# Altium schematic sheet Battery Management System.SchDoc
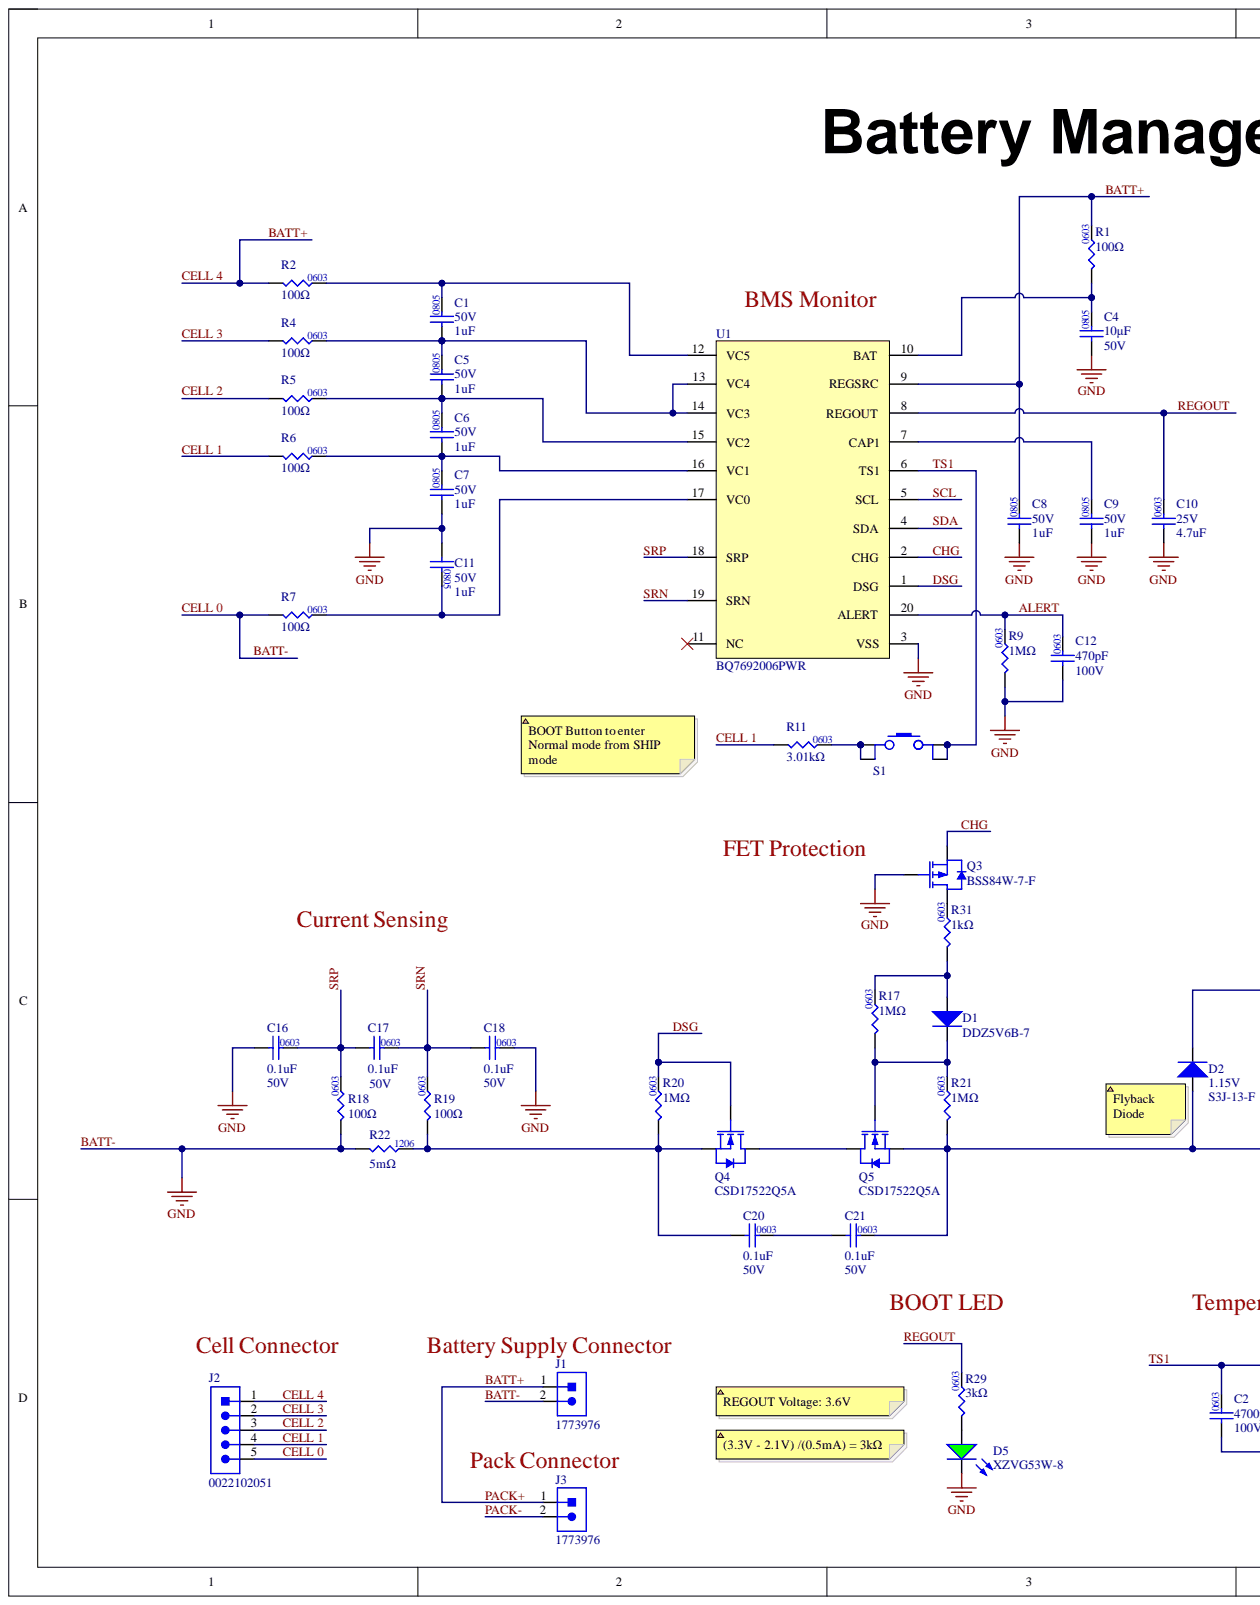
[diagram p0: Battery Management System.SchDoc, left]
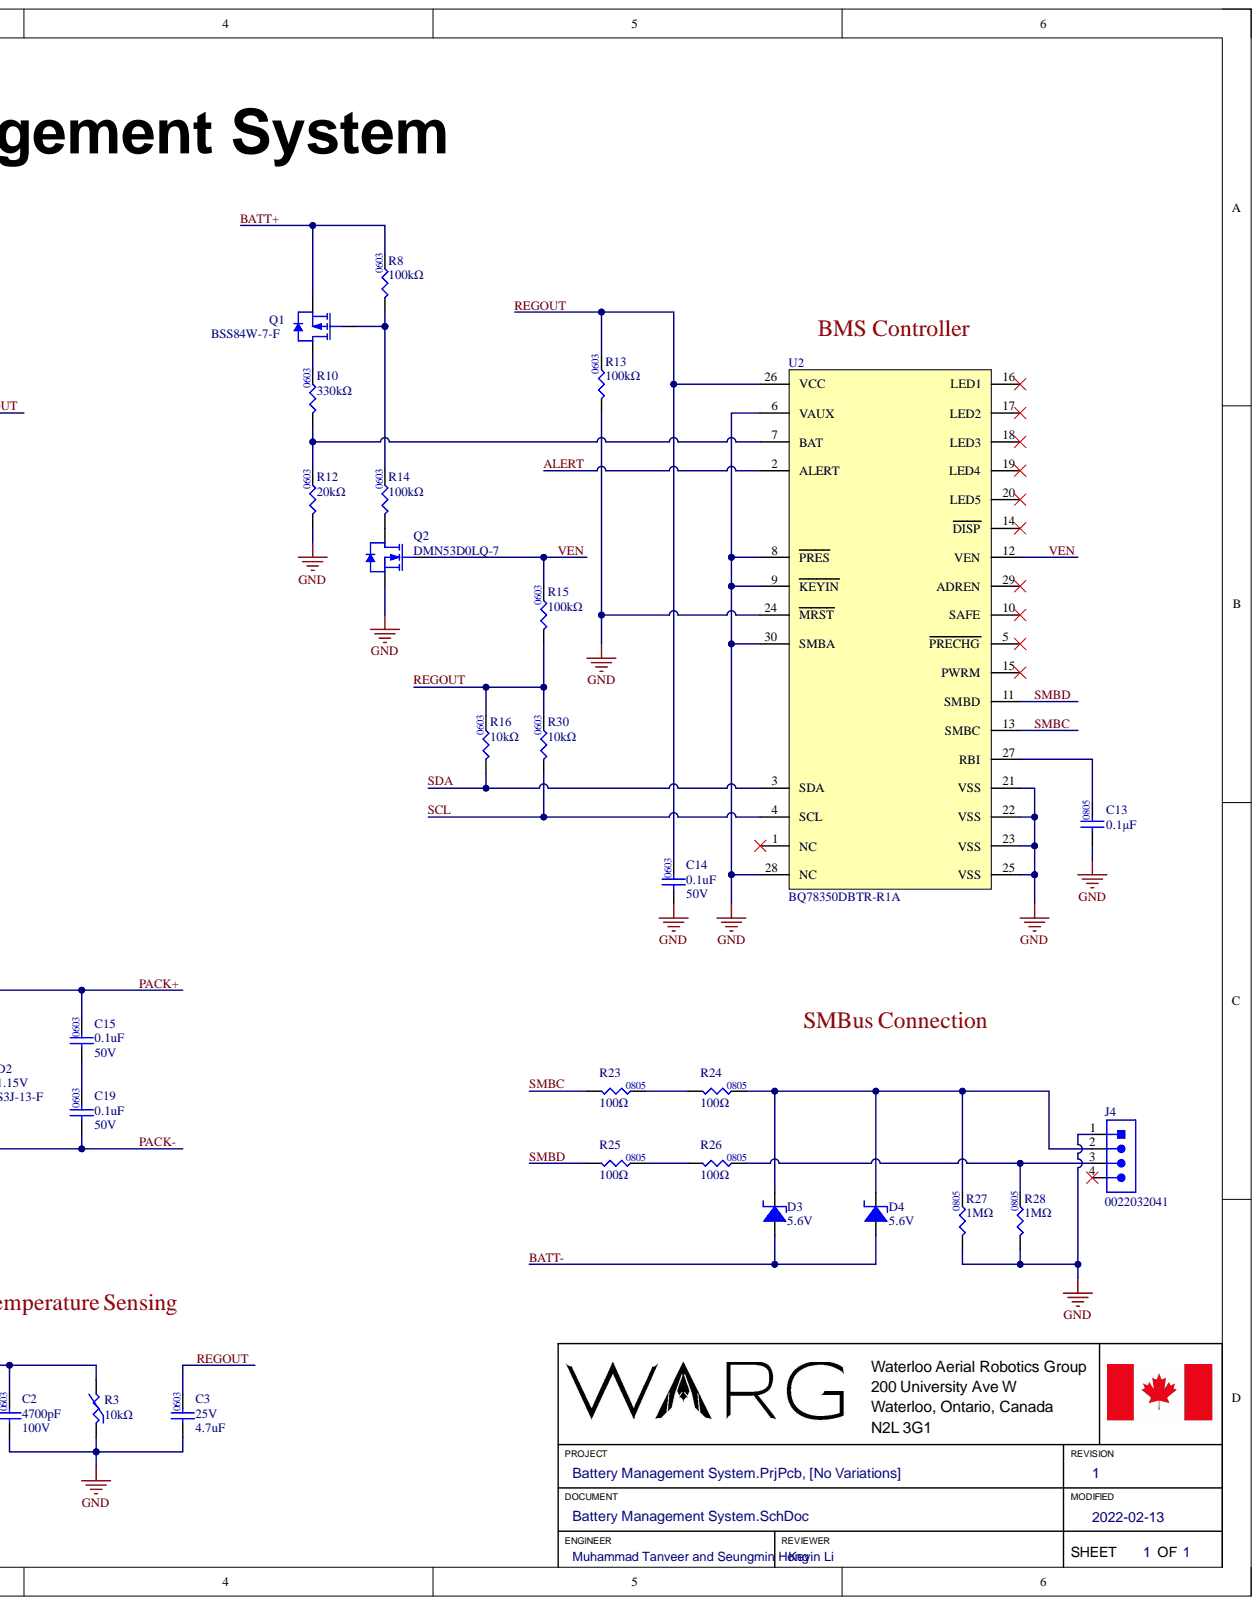
[diagram p1: Battery Management System.SchDoc, right]

## Parts
C1  value 1uF  Package: 0805  Voltage: 50V  [CAP0805]  (hidden comment: CAP_1uF_50V_0805)
C2  value 4700pF  Package: 0603  Voltage Rating: 100V  [CAP0603]  (hidden comment: CAP_4700pF_100V_0603_TDK)
C3  value 4.7uF  Package: 0603  Voltage: 25V  [CAP0603]  (hidden comment: CAP_4.7uF_25V_0603)
C4  value 10μF  Package: 0805  Voltage: 50V  [CAP0805]  (hidden comment: CAP_10uF_50V_0805)
C5  value 1uF  Package: 0805  Voltage: 50V  [CAP0805]  (hidden comment: CAP_1uF_50V_0805)
C6  value 1uF  Package: 0805  Voltage: 50V  [CAP0805]  (hidden comment: CAP_1uF_50V_0805)
C7  value 1uF  Package: 0805  Voltage: 50V  [CAP0805]  (hidden comment: CAP_1uF_50V_0805)
C8  value 1uF  Package: 0805  Voltage: 50V  [CAP0805]  (hidden comment: CAP_1uF_50V_0805)
C9  value 1uF  Package: 0805  Voltage: 50V  [CAP0805]  (hidden comment: CAP_1uF_50V_0805)
C10  value 4.7uF  Package: 0603  Voltage: 25V  [CAP0603]  (hidden comment: CAP_4.7uF_25V_0603)
C11  value 1uF  Package: 0805  Voltage: 50V  [CAP0805]  (hidden comment: CAP_1uF_50V_0805)
C12  value 470pF  Package: 0603  Voltage Rating: 100V  [CAP0603]  (hidden comment: CAP_470pF_100V_0603)
C13  0.1µF  [CAP0805]
C14  value 0.1uF  Package: 0603  Voltage: 50V  [CAP0603]  (hidden comment: CAP_0.1uF_50V_0603)
C15  value 0.1uF  Package: 0603  Voltage: 50V  [CAP0603]  (hidden comment: CAP_0.1uF_50V_0603)
C16  value 0.1uF  Package: 0603  Voltage: 50V  [CAP0603]  (hidden comment: CAP_0.1uF_50V_0603)
C17  value 0.1uF  Package: 0603  [CAP0603]  (hidden comment: CAP_0.1uF_50V_0603)
C18  value 0.1uF  Package: 0603  Voltage: 50V  [CAP0603]  (hidden comment: CAP_0.1uF_50V_0603)
C19  value 0.1uF  Package: 0603  Voltage: 50V  [CAP0603]  (hidden comment: CAP_0.1uF_50V_0603)
C20  value 0.1uF  Package: 0603  Voltage: 50V  [CAP0603]  (hidden comment: CAP_0.1uF_50V_0603)
C21  value 0.1uF  Package: 0603  Voltage: 50V  [CAP0603]  (hidden comment: CAP_0.1uF_50V_0603)
D1  Manufacturer Part Number 1: DDZ5V6B-7  [DIO-SOD123]  (hidden comment: DIO_100V_150mA)
D2  Forward Voltage: 1.15V  Manufacturer Part Number 1: S3J-13-F  [SMC]  (hidden comment: DIO_600V_3A)
D3  Zener Voltage: 5.6V  [DIO-SOD123]  (hidden comment: DIO_ZENER_5.6V)
D4  Zener Voltage: 5.6V  [DIO-SOD123]  (hidden comment: DIO_ZENER_5.6V)
D5  Manufacturer Part Number 1: XZVG53W-8  [LED0603]  (hidden comment: LED_GREEN_2.1V_0603)
J1  CON_2POS_SCREW_TERM  [1773976]  (value not drawn)
J2  Manufacturer Part Number 1: 0022102051  [0022032051]  (hidden comment: CON_5X1_2.54MM_MALE_TH_HDR_2)
J3  CON_2POS_SCREW_TERM  [1773976]  (value not drawn)
J4  CON_4POSX2.54_MALE_HDR_UNSHROUDED  [0022032041]  (value not drawn)
Q1  Manufacturer Part Number 1: BSS84W-7-F  [8SOIC]  (hidden comment: PMOS_50V_130mA)
Q2  Manufacturer Part Number 1: DMN53D0LQ-7  [SOT23-3]  (hidden comment: NMOS_50V_500mA)
Q3  Manufacturer Part Number 1: BSS84W-7-F  [8SOIC]  (hidden comment: PMOS_50V_130mA)
Q4  NMOS_30V_87A  [CSD17522Q5A]  (value not drawn)
Q5  NMOS_30V_87A  [CSD17522Q5A]  (value not drawn)
R1  value 100Ω  Package: 0603  [RES0603]  (hidden comment: RES_0Ω_0603)
R2  value 100Ω  Package: 0603  [RES0603]  (hidden comment: RES_0Ω_0603)
R3  value 10kΩ  [RES_SEMITEC_10k]  (hidden comment: NTC_THERM_10kΩ_1%)
R4  value 100Ω  Package: 0603  [RES0603]  (hidden comment: RES_0Ω_0603)
R5  value 100Ω  Package: 0603  [RES0603]  (hidden comment: RES_0Ω_0603)
R6  value 100Ω  Package: 0603  [RES0603]  (hidden comment: RES_0Ω_0603)
R7  value 100Ω  Package: 0603  [RES0603]  (hidden comment: RES_0Ω_0603)
R8  value 100kΩ  Package: 0603  [RES0603]  (hidden comment: RES_100kΩ_0603_1%)
R9  value 1MΩ  Package: 0603  [RES0603]  (hidden comment: RES_1MΩ_0603_1%)
R10  Package: 0603  Resistance: 330kΩ  [RES0603]  (hidden comment: RES_330kΩ_0603_1%)
R11  Package: 0603  Resistance: 3.01kΩ  [RES0603]  (hidden comment: RES_3.01kΩ_0603_1%)
R12  Package: 0603  Resistance: 20kΩ  [RES0603]  (hidden comment: RES_20kΩ_0603_1%)
R13  value 100kΩ  Package: 0603  [RES0603]  (hidden comment: RES_100kΩ_0603_1%)
R14  value 100kΩ  Package: 0603  [RES0603]  (hidden comment: RES_100kΩ_0603_1%)
R15  value 100kΩ  Package: 0603  [RES0603]  (hidden comment: RES_100kΩ_0603_1%)
R16  value 10kΩ  Package: 0603  [RES0603]  (hidden comment: RES_10kΩ_0603_1%)
R17  value 1MΩ  Package: 0603  [RES0603]  (hidden comment: RES_1MΩ_0603_1%)
R18  value 100Ω  Package: 0603  [RES0603]  (hidden comment: RES_0Ω_0603)
R19  value 100Ω  Package: 0603  [RES0603]  (hidden comment: RES_0Ω_0603)
R20  value 1MΩ  Package: 0603  [RES0603]  (hidden comment: RES_1MΩ_0603_1%)
R21  value 1MΩ  Package: 0603  [RES0603]  (hidden comment: RES_1MΩ_0603_1%)
R22  Resistance: 5mΩ  [RES1206]  (hidden comment: RES_5mΩ_1206_1%)
R23  100Ω  [RES0805]
R24  100Ω  [RES0805]
R25  100Ω  [RES0805]
R26  100Ω  [RES0805]
R27  1MΩ  [RES0805]
R28  1MΩ  [RES0805]
R29  Package: 0603  Resistance: 3kΩ  [RES0603]  (hidden comment: RES_3kΩ_0603_1%)
R30  value 10kΩ  Package: 0603  [RES0603]  (hidden comment: RES_10kΩ_0603_1%)
R31  value 1kΩ  Package: 0603  [RES0603]  (hidden comment: RES_1kΩ_0603_1%)
S1  SWITCH_SPST_NO  [TL3301NF160QG/TR]  (value not drawn)
U1  BQ7692006PWR  [BQ7692006PWR]
U2  BQ78350DBTR-R1A  [BQ78350DBTR-R1A]

## Nets
ALERT: C12.2 R9.2 U1.20(ALERT) U2.2(ALERT)
BATT+: C8.2 C15.2 D2.2 J1.1 J2.1 J3.1 Q1.1 R1.2 R2.1 R8.2 U1.9(REGSRC)
BATT-: C2.1 C3.1 C4.1 C7.1 C8.1 C9.1 C10.1 C11.1 C12.1 C13.1 C14.1 C16.1 C18.2 D3.1 D4.1 D5.2 J1.2 J2.5 J4.1 Q2.1 Q3.2 R3.1 R7.1 R9.1 R12.1 R13.1 R18.1 R22.1 R27.1 R28.1 U1.3(VSS) U2.6(VAUX) U2.8(PRES) U2.9(KEYIN) U2.21(VSS) U2.22(VSS) U2.23(VSS) U2.24(MRST) U2.25(VSS) U2.28(NC) U2.30(SMBA)
CELL 1: J2.4 R6.1 R11.1
CELL 2: J2.3 R5.1
CELL 3: J2.2 R4.1
CHG: Q3.1 U1.2(CHG)
DSG: Q4.2 R20.2 U1.1(DSG)
PACK-: C19.1 C21.2 D2.1 J3.2 Q5.1 R21.1
REGOUT: C3.2 C10.2 C14.2 R13.2 R15.1 R16.2 R29.2 R30.2 U1.8(REGOUT) U2.26(VCC)
SCL: R30.1 U1.5(SCL) U2.4(SCL)
SDA: R16.1 U1.4(SDA) U2.3(SDA)
SMBC: R23.1 U2.13(SMBC)
SMBD: R25.1 U2.11(SMBD)
SRN: C17.2 C18.1 R19.2 U1.19(SRN)
SRP: C16.2 C17.1 R18.2 U1.18(SRP)
TS1: C2.2 R3.2 S1.3 S1.4 U1.6(TS1)
VEN: Q2.2 R15.2 U2.12(VEN)
NetC1_1: C1.1 C5.2 R4.2 U1.13(VC4) U1.14(VC3)
NetC1_2: C1.2 R2.2 U1.12(VC5)
NetC4_2: C4.2 R1.1 U1.10(BAT)
NetC5_1: C5.1 C6.2 R5.2 U1.15(VC2)
NetC6_1: C6.1 C7.2 R6.2 U1.16(VC1)
NetC9_2: C9.2 U1.7(CAP1)
NetC11_2: C11.2 R7.2 U1.17(VC0)
NetC13_2: C13.2 U2.27(RBI)
NetC15_1: C15.1 C19.2
NetC20_1: C20.1 Q4.1 R19.1 R20.1 R22.2
NetC20_2: C20.2 C21.1
NetD1_1: D1.1 R17.2 R31.1
NetD1_2: D1.2 Q5.2 R17.1 R21.2
NetD3_2: D3.2 D4.2 J4.2 R24.2 R27.2
NetD5_1: D5.1 R29.1
NetJ4_3: J4.3 R26.2 R28.2
NetQ1_2: Q1.2 R8.1 R14.2
NetQ1_3: Q1.3 R10.2
NetQ2_3: Q2.3 R14.1
NetQ3_3: Q3.3 R31.2
NetQ4_3: Q4.3 Q5.3
NetR10_1: R10.1 R12.2 U2.7(BAT)
NetR11_2: R11.2 S1.1 S1.2
NetR23_2: R23.2 R24.1
NetR25_2: R25.2 R26.1

Not connected: J4 4; U1 11(NC); U2 1(NC) 5(PRECHG) 10(SAFE) 14(DISP) 15(PWRM) 16(LED1) 17(LED2) 18(LED3) 19(LED4) 20(LED5) 29(ADREN)

## Library data of the parts
C1 C5-C9 C11 = CAP_1uF_50V_0805_MURATA: CAP CER 1UF 50V X7R ±10% 0805; Manufacturer 1: Murata Electronics; Manufacturer Part Number 1: GCJ21BR71H105KA01L; Component Kind: Standard; Mounting Type: Surface Mount; Supplier 1: Digi-Key; Supplier Part Number 1: 490-10667-1-ND; Temperature Coefficient: X7R; Tolerance: ±10%; Library Name: WARG.SchLib
C2 = CAP_4700pF_100V_0603_TDK: CAP CER 4700PF 100V X7R 0603; Manufacturer 1: TDK Corporation; Manufacturer Part Number 1: CGA3E2X7R2A472K080AA; Temperature Coefficient: C0G/NP0; Tolerance: ±10%; Component Kind: Standard; Mounting Type: Surface Mount; Supplier 1: Digi-Key; Supplier Part Number 1: 445-5810-1-ND; Library Name: WARG.SchLib
C3 C10 = CAP_4.7uF_25V_0603_MURATA: CAP CER 4.7UF 25V X6S ±10% 0603; Manufacturer 1: Murata Electronics; Manufacturer Part Number 1: GRM188C81E475KE11D; Component Kind: Standard; Mounting Type: Surface Mount; Supplier 1: Digi-Key; Supplier Part Number 1: 490-7199-1-ND; Temperature Coefficient: X6S; Tolerance: ±10%; Library Name: WARG.SchLib
C4 = CAP_10uF_50V_0805_MURATA: CAP CER 10UF 50V X5R ±10% 0805; Manufacturer 1: Murata Electronics; Manufacturer Part Number 1: GRM21BR61H106KE43L; Component Kind: Standard; LCSC Part #: C440198; Mounting Type: Surface Mount; Supplier 1: LCSC; Supplier 2: Digi-Key; Supplier Part Number 1: C440198; Supplier Part Number 2: 490-18663-1-ND; Temperature Coefficient: X5R; Tolerance: ±10%; Library Name: WARG.SchLib
C12 = CAP_470pF_100V_0603_MURATA: CAP CER 470PF 100V C0G/NP0 ±5% 0603; Manufacturer 1: Murata Electronics; Manufacturer Part Number 1: GCM1885C2A471JA16D; Component Kind: Standard; Mounting Type: Surface Mount; Supplier 1: Digi-Key; Supplier Part Number 1: 490-4949-1-ND; Temperature Coefficient: C0G/NP0; Tolerance: ±5%; Library Name: WARG.SchLib
C13 = CAP_0805: Generic 0805 cap; Component Kind: Standard; Library Name: WARG.SchLib
C14-C21 = CAP_0.1uF_50V_0603_KEMET: CAP CER 0.1UF 50V X7R ±10% 0603; Manufacturer 1: Walsin Technology Corporation; Manufacturer Part Number 1: 0603B104K500CT; Component Kind: Standard; Mounting Type: Surface Mount; Supplier 1: DigiKey; Supplier Part Number 1: 1292-1643-1-ND; Temperature Coefficient: X7R; Tolerance: ±10%; Library Name: WARG.SchLib
  C17: Voltage: 50V
D1 = DIO_100V_150mA: DIODE GEN PURP 100V 150MA SOD123; Manufacturer 1: Diodes Incorporated; Forward Voltage: 0.855V @ 10mA; Maximum Power: 400mW; Reverse Leakage Current: 1 μA @ 75V; Component Kind: Standard; Mounting Type: Surface Mount; Package: SOD-123; Supplier 1: Digi-Key; Supplier Part Number 1: BAV16W-FDICT-ND; Library Name: WARG.SchLib
D2 = DIO_600V_3A: DIODE GEN PURP 600V 3A SMC; Manufacturer 1: Diodes Incorporated; Reverse Leakage Current: 10 μA @ 600V; Average Rectified Current: 3A; Component Kind: Standard; Continuous Reverse Voltage (Max): 600V; Mounting Type: Surface Mount; Package: SMC; Supplier 1: Digi-Key; Supplier Part Number 1: S3J-FDICT-ND; Library Name: WARG.SchLib
D3 D4 = DIO_ZENER_5.6V: DIODE ZENER 5.6V 370MW SOD123; Manufacturer 1: Diodes Incorporated; Manufacturer Part Number 1: BZT52C5V6-13-F; Forward Voltage: 900 mV @ 10 mA; Reverse Leakage Current: 1 µA @ 2 V; Component Kind: Standard; Maximum Power: 370mW; Mounting Type: Surface Mount; Package: SOD-123; Supplier 1: Digi-Key; Supplier Part Number 1: BZT52C5V6-13-FDICT-ND; Library Name: WARG.SchLib
D5 = LED_GREEN_2.1V_0603: LED GREEN 2.1V 0603; Manufacturer 1: SunLED; Color: Green; Component Kind: Standard; Forward Voltage: 2.1V; Mounting Type: Surface Mount; Package: 0603; Supplier 1: Digi-Key; Supplier Part Number 1: 1497-1215-1-ND; Library Name: WARG.SchLib
J1 J3 = TERM BLK 2P SIDE ENT 10.16MM PCB: Pitch: 5mm; Component Kind: Standard; Description: TERM BLK 2P SIDE ENT 10.16MM PCB; Manufacturer 1: 1773976; Manufacturer Part Number 1: 1773976; Mounting Type: Through Hole; Supplier 1: Digi-Key; Supplier Part Number 1: 277-5761-ND; Library Name: WARG.SchLib
J2 = CON_5X1_2.54MM_MALE_TH_HDR_2: CONN HEADER VERT 5POS 2.54MM; Manufacturer 1: Molex; Number of Positions: 5; Component Kind: Standard; Connector Type: Header; Contact Type: Male Pin; Mounting Type: Through Hole; Number of Rows: 1; Pitch: 2.54mm; Supplier 1: Digi-Key; Supplier Part Number 1: 23-0022102051-ND; Library Name: WARG.SchLib
J4 = CON_4POSX2.54_MALE_HDR_UNSHROUDED: CONN HEADER VERT 4POS 2.54MM. Connector Header Through Hole 4 position 0.100" (2.54mm).; Manufacturer 1: Molex; Component Kind: Standard; Manufacturer 1 Part Number: 0022032041; Supplier 1: Digi-Key; Supplier Part Number 1: WM4002-ND; Library Name: WARG.SchLib
Q1 Q3 = PMOS_50V_130mA: MOSFET P-CH 50V 130mA SOT323; Manufacturer 1: Diodes Incorporated; Continuous Drain Current (Id): -130mA; Drain to Source Resistance (Rds(on)) Max.: 10Ω; Drain to Source Voltage (Vdss) Max.: -50V; Gate Threshold Voltage (Vgs(th)): -1.6V; Gate to Source Voltage (Vgs): ±20V; Component Kind: Standard; Package: SOT-323; Supplier 1: Digi-Key; Supplier Part Number 1: BSS84W-FDICT-ND; Library Name: WARG.SchLib
Q2 = NMOS_50V_500mA: MOSFET N-CH 50V 500MA SOT23; Manufacturer 1: Diodes Incorporated; Drain to Source Resistance On (Rds(on)) Max.: 1.6Ω; FET Type: N-Channel; Gate to Source Voltage (Vgs) Max. @ Vgs = 4.5V: ±20V; Gate to Source Voltage Threshold (Vgs(th)) Max.: 1.5V; Component Kind: Standard; Continuous Drain Current (Id) TA=25°C: 500mA; Drain to Source Voltage (Vdss) Max.: 50V; Mounting Type: Surface Mount; Package: SOT23-3; Supplier 1: Digi-Key; Supplier Part Number 1: DMN53D0LQ-7DICT-ND; Library Name: WARG.SchLib
Q4 Q5 = NMOS_30V_87A: MOSFET N-CH 30V 87A 8VSON; Manufacturer 1: Infineon Technologies; Max Power Dissipation: 3W; Component Kind: Standard; Manufacturer Part Number 1: CSD17522Q5A; Supplier 1: Digi-Key; Supplier Part Number 1: 296-29020-1-ND; Library Name: WARG.SchLib
R1 R2 R4-R7 R18 R19 = RES_100Ω_0603: RES 100 OHM 5% 1/10W 0603; Manufacturer 1: YAGEO; Manufacturer Part Number 1: RC0603JR-13100RL; Component Kind: Standard; Mounting Type: Surface Mount; Power Rating: 1/10W; Supplier 1: Digi-Key; Supplier Part Number 1: 13-RC0603JR-13100RLCT-ND; Library Name: WARG.SchLib
R3 = NTC_THERM_10kΩ_1%: NTC Thermistors 10kohm 1%; Manufacturer 1: Semitec; Manufacturer Part Number 1: 103AT-2; Component Kind: Standard; Package: Bulk; Supplier 1: Mouser; Supplier Part Number 1: 954-103AT-2; Tolerance: ±1%; Library Name: WARG.SchLib
R8 R13-R15 = RES_100kΩ_0603_1%: RES 100K OHM 1% 1/10W 0603; Manufacturer 1: Stackpole Electronics Inc; Manufacturer Part Number 1: RMCF0603FT100K; Component Kind: Standard; Mounting Type: Surface Mount; Power Rating: 1/10W; Supplier 1: Digi-Key; Supplier Part Number 1: RMCF0603FT100KCT-ND; Tolerance: ±1%; Library Name: WARG.SchLib
R9 R17 R20 R21 = RES_1MΩ_0603_5%: RES 1M OHM 5% 1/10W 0603; Manufacturer 1: Stackpole Electronics Inc; Manufacturer Part Number 1: RMCF0603JT1M00; Component Kind: Standard; Mounting Type: Surface Mount; Power Rating: 1/10W; Supplier 1: Digi-Key; Supplier Part Number 1: RMCF0603JT1M00CT-ND; Tolerance: ±5%; Library Name: WARG.SchLib
R10 = RES_330kΩ_0603_1%: RES 330K OHM ±1% 1/10W 0603; Manufacturer 1: Stackpole Electronics Inc; Manufacturer Part Number 1: RMCF0603FT330K; Component Kind: Standard; Mounting Type: Surface Mount; Power Rating: 1/10W; Supplier 1: Digi-Key; Supplier Part Number 1: RMCF0603FT330KCT-ND; Tolerance: ±1%; Library Name: WARG.SchLib
R11 = RES_3.01kΩ_0603_1%: RES 3.01K OHM 1% 1/10W 0603; Manufacturer 1: YAGEO; Manufacturer Part Number 1: RC0603FR-073K01L; Component Kind: Standard; Mounting Type: Surface Mount; Power Rating: 1/10W; Supplier 1: Digi-Key; Supplier Part Number 1: 311-3.01KHRCT-ND; Tolerance: ±1%; Library Name: WARG.SchLib
R12 = RES_20kΩ_0603_1%: RES 20K OHM ±1% 1/10W 0603; Manufacturer 1: YAGEO; Manufacturer Part Number 1: RC0603FR-0720KL; Component Kind: Standard; Mounting Type: Surface Mount; Power Rating: 1/10W; Supplier 1: Digi-Key; Supplier Part Number 1: 311-20.0KHRCT-ND; Tolerance: ±1%; Library Name: WARG.SchLib
R16 R30 = RES_10kΩ_0603_1%: RES 10K OHM ±1% 1/10W 0603; Manufacturer 1: Stackpole Electronics Inc; Manufacturer Part Number 1: RMCF0603FT10K0; Component Kind: Standard; Mounting Type: Surface Mount; Power Rating: 1/10W; Supplier 1: Digi-Key; Supplier Part Number 1: RMCF0603FT10K0CT-ND; Tolerance: ±1%; Library Name: WARG.SchLib
R22 = RES_5mΩ_1206_1%: RES 0.005 OHM ±1% 1/4W 1206; Component Kind: Standard; Supplier 1: Digi-Key; Supplier Part Number 1: 13-PE1206FRF070R005LCT-ND; Library Name: WARG.SchLib
R23-R26 = RES_0805: RES 100 OHM 1% 1/10W 0805; Value: 1MΩ; Component Kind: Standard; Library Name: WARG.SchLib
R27 R28 = RES_0805: RES 100 OHM 1% 1/10W 0805; Component Kind: Standard; Value: 1MΩ; Library Name: WARG.SchLib
R29 = RES_3kΩ_0603_1%: RES 3K OHM 1% 1/10W 0603; Manufacturer 1: YAGEO; Manufacturer Part Number 1: RC0603FR-073KL; Component Kind: Standard; Mounting Type: Surface Mount; Power Rating: 1/10W; Supplier 1: Digi-Key; Supplier Part Number 1: 311-3.00KHRCT-ND; Tolerance: ±1%; Library Name: WARG.SchLib
R31 = RES_1kΩ_0603_1%: RES 1K OHM 1% 1/10W 0603; Manufacturer 1: Stackpole Electronics Inc; Manufacturer Part Number 1: RMCF0603FT1K00; Component Kind: Standard; Mounting Type: Surface Mount; Power Rating: 1/10W; Supplier 1: Digi-Key; Supplier Part Number 1: RMCF0603FT1K00CT-ND; Tolerance: ±1%; Library Name: WARG.SchLib
S1 = SWITCH_SPST_NO: SWITCH TACTILE SPST-NO 0.05A 12V; Manufacturer 1: E-Switch; Circuit: SPST-NO; Component Kind: Standard; Manufacturer Part Number 1: TL3301NF160QG/TR; Mounting Type: Surface Mount; Supplier 1: Digi-Key; Supplier Part Number 1: EG1867CT-ND; Library Name: WARG.SchLib
U1 = BQ7692006PWR: IC BATT MGMT LI-ION AFE 20TSSOP; Manufacturer 1: Texas Instruments; Component Kind: Standard; Manufacturer Part Number 1: BQ7692006PWR; Mounting Type: Surface Mount; Supplier 1: Digi-Key; Supplier 2: LCSC; Supplier Part Number 1: 296-39960-1-ND; Library Name: WARG.SchLib
U2 = BQ78350DBTR-R1A: IC BATT MON LI-ION 3-15C 30TSSOP; Manufacturer 1: Texas Instruments; Component Kind: Standard; Manufacturer Part Number 1: BQ78350DBTR-R1A; Mounting Type: Surface Mount; Supplier 1: Digi-Key; Supplier 2: LCSC; Supplier Part Number 1: 296-BQ78350DBTR-R1ACT-ND; Library Name: WARG.SchLib
